# Altium schematic sheet Power Control Circuitry (updated design).SchDoc("Power Control Circuitry (updated design)")
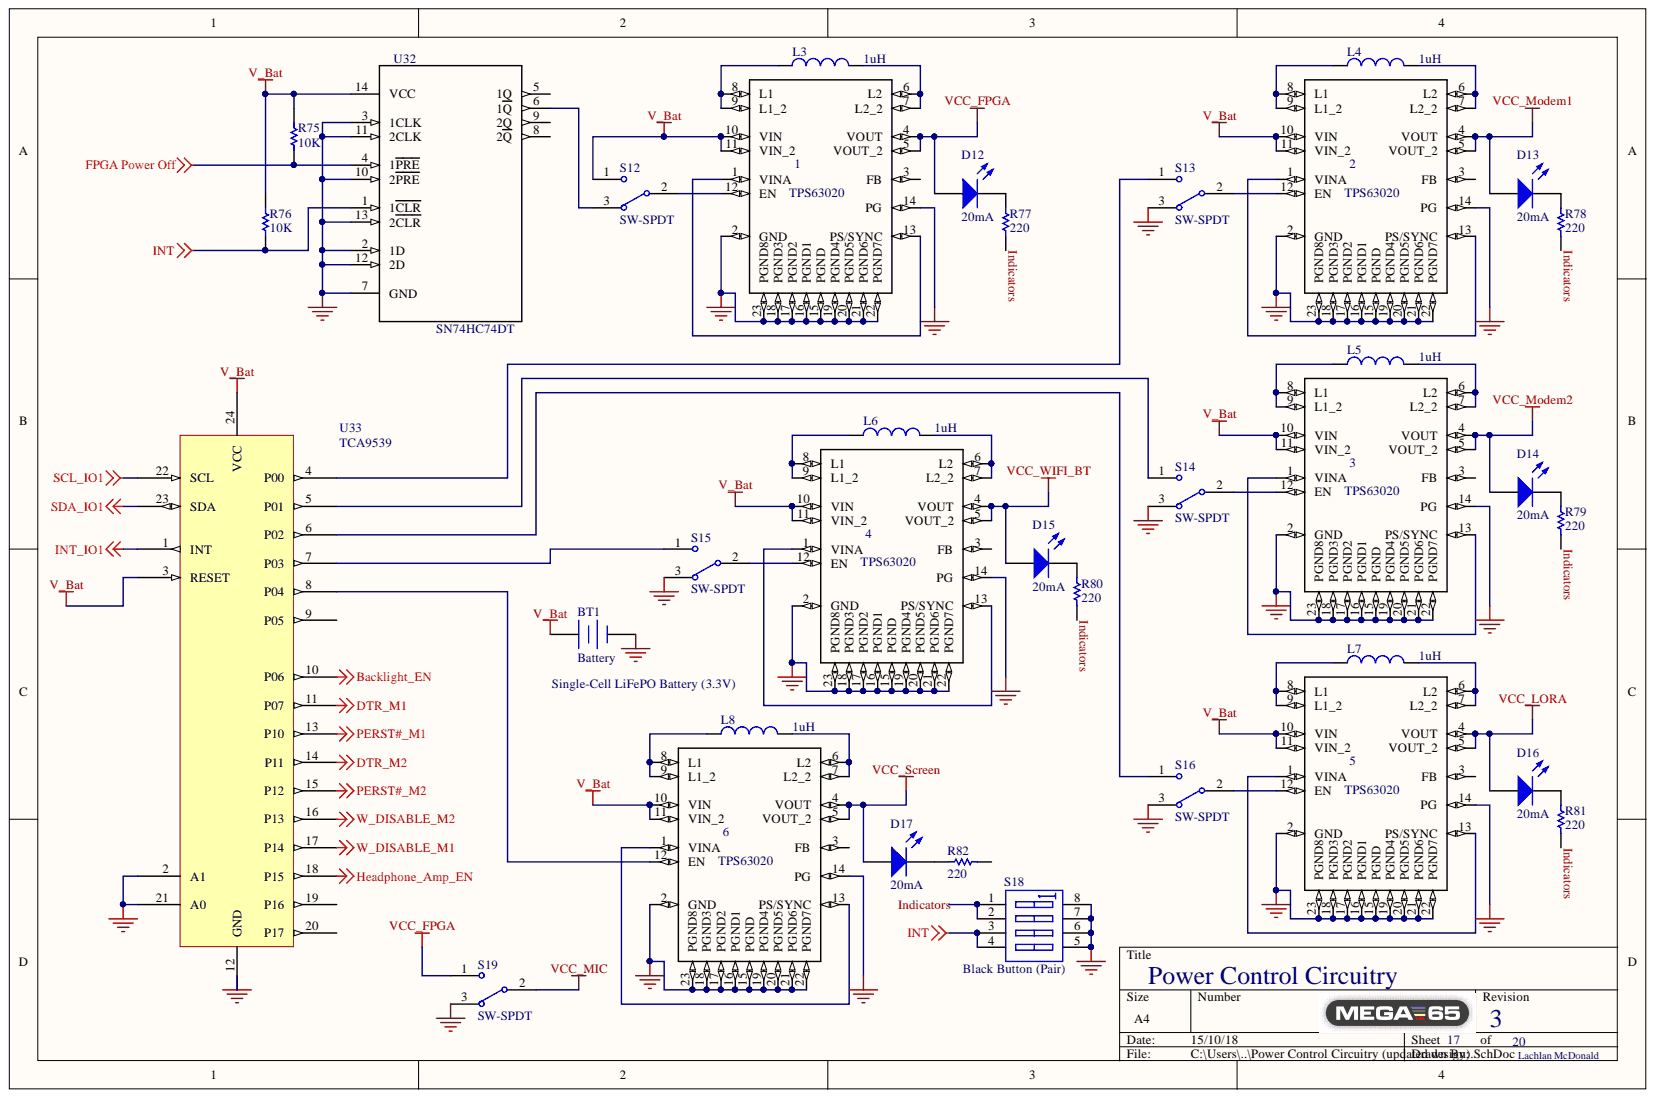
[diagram p0: Power Control Circuitry ]

## Parts
1  TPS63020  [QFN14-3X4MM]
2  TPS63020  [QFN14-3X4MM]
3  TPS63020  [QFN14-3X4MM]
4  TPS63020  [QFN14-3X4MM]
5  TPS63020  [QFN14-3X4MM]
6  TPS63020  [QFN14-3X4MM]
BT1  Battery  [BAT-2]
D12  20mA  [LEDs]
D13  20mA  [LEDs]
D14  20mA  [LEDs]
D15  20mA  [LEDs]
D16  20mA  [LEDs]
D17  20mA  [LEDs]
L3  value 1uH  [0402-A]  (hidden comment: Inductor)
L4  value 1uH  [0402-A]  (hidden comment: Inductor)
L5  value 1uH  [0402-A]  (hidden comment: Inductor)
L6  value 1uH  [0402-A]  (hidden comment: Inductor)
L7  value 1uH  [0402-A]  (hidden comment: Inductor)
L8  value 1uH  [0402-A]  (hidden comment: Inductor)
R75  10K  [RESC0805(2012)_M]
R76  10K  [RESC0805(2012)_M]
R77  220  [RESC0805(2012)_M]
R78  220  [RESC0805(2012)_M]
R79  220  [RESC0805(2012)_M]
R80  220  [RESC0805(2012)_M]
R81  220  [RESC0805(2012)_M]
R82  220  [RESC0805(2012)_M]
S12  SW-SPDT  [Switch Slide SPDT]
S13  SW-SPDT  [Switch Slide SPDT]
S14  SW-SPDT  [Switch Slide SPDT]
S15  SW-SPDT  [Switch Slide SPDT]
S16  SW-SPDT  [Switch Slide SPDT]
S18  Black Button (Pair)  [Black Button]
S19  SW-SPDT  [Switch Slide SPDT]
U32  SN74HC74DT  [SOIC127P600X175-14N]
U33  TCA9539  [PW24]

## Nets
Backlight_EN: U33.10(P06)
DTR_M1: U33.11(P07)
DTR_M2: U33.14(P11)
FPGA Power Off: R75.2 U32.4(1PRE)
GND: 1.2(GND) 1.14(PG) 1.15(PGND) 1.16(PGND1) 1.17(PGND2) 1.18(PGND3) 1.19(PGND4) 1.20(PGND5) 1.21(PGND6) 1.22(PGND7) 1.23(PGND8) 2.2(GND) 2.14(PG) 2.15(PGND) 2.16(PGND1) 2.17(PGND2) 2.18(PGND3) 2.19(PGND4) 2.20(PGND5) 2.21(PGND6) 2.22(PGND7) 2.23(PGND8) 3.2(GND) 3.14(PG) 3.15(PGND) 3.16(PGND1) 3.17(PGND2) 3.18(PGND3) 3.19(PGND4) 3.20(PGND5) 3.21(PGND6) 3.22(PGND7) 3.23(PGND8) 4.2(GND) 4.14(PG) 4.15(PGND) 4.16(PGND1) 4.17(PGND2) 4.18(PGND3) 4.19(PGND4) 4.20(PGND5) 4.21(PGND6) 4.22(PGND7) 4.23(PGND8) 5.2(GND) 5.14(PG) 5.15(PGND) 5.16(PGND1) 5.17(PGND2) 5.18(PGND3) 5.19(PGND4) 5.20(PGND5) 5.21(PGND6) 5.22(PGND7) 5.23(PGND8) 6.2(GND) 6.14(PG) 6.15(PGND) 6.16(PGND1) 6.17(PGND2) 6.18(PGND3) 6.19(PGND4) 6.20(PGND5) 6.21(PGND6) 6.22(PGND7) 6.23(PGND8) BT1.2 S13.3 S14.3 S15.3 S16.3 S18.5 S18.6 S18.7 S18.8 S19.3 U32.2(1D) U32.3(1CLK) U32.7(GND) U32.10(2PRE) U32.11(2CLK) U32.12(2D) U32.13(2CLR) U33.2(A1) U33.12(GND) U33.21(A0)
Headphone_Amp_EN: U33.18(P15)
INT: R76.2 S18.3 S18.4 U32.1(1CLR)
INT_IO1: U33.1(INT)
PERST#_M1: U33.13(P10)
PERST#_M2: U33.15(P12)
SCL_IO1: U33.22(SCL)
SDA_IO1: U33.23(SDA)
V_Bat: 1.10(VIN) 1.11(VIN_2) 2.10(VIN) 2.11(VIN_2) 3.10(VIN) 3.11(VIN_2) 4.10(VIN) 4.11(VIN_2) 5.10(VIN) 5.11(VIN_2) 6.10(VIN) 6.11(VIN_2) BT1.1 R75.1 R76.1 S12.1 U32.14(VCC) U33.3(RESET) U33.24(VCC)
VCC_FPGA: 1.4(VOUT) 1.5(VOUT_2) D12.1 S19.1
VCC_LORA: 5.4(VOUT) 5.5(VOUT_2) D16.1
VCC_MIC: S19.2
VCC_Modem1: 2.4(VOUT) 2.5(VOUT_2) D13.1
VCC_Modem2: 3.4(VOUT) 3.5(VOUT_2) D14.1
VCC_Screen: 6.4(VOUT) 6.5(VOUT_2) D17.1
VCC_WIFI_BT: 4.4(VOUT) 4.5(VOUT_2) D15.1
W_DISABLE_M1: U33.17(P14)
W_DISABLE_M2: U33.16(P13)
Indicators: R77.2 R78.2 R79.2 R80.2 R81.2 S18.1 S18.2
Net1_1: 1.1(VINA) 1.13(PS/SYNC)
Net1_6: 1.6(L2) 1.7(L2_2) L3.2
Net1_8: 1.8(L1) 1.9(L1_2) L3.1
Net1_12: 1.12(EN) S12.2
Net2_1: 2.1(VINA) 2.13(PS/SYNC)
Net2_6: 2.6(L2) 2.7(L2_2) L4.2
Net2_8: 2.8(L1) 2.9(L1_2) L4.1
Net2_12: 2.12(EN) S13.2
Net3_1: 3.1(VINA) 3.13(PS/SYNC)
Net3_6: 3.6(L2) 3.7(L2_2) L5.2
Net3_8: 3.8(L1) 3.9(L1_2) L5.1
Net3_12: 3.12(EN) S14.2
Net4_1: 4.1(VINA) 4.13(PS/SYNC)
Net4_6: 4.6(L2) 4.7(L2_2) L6.2
Net4_8: 4.8(L1) 4.9(L1_2) L6.1
Net4_12: 4.12(EN) S15.2
Net5_1: 5.1(VINA) 5.13(PS/SYNC)
Net5_6: 5.6(L2) 5.7(L2_2) L7.2
Net5_8: 5.8(L1) 5.9(L1_2) L7.1
Net5_12: 5.12(EN) S16.2
Net6_1: 6.1(VINA) 6.13(PS/SYNC)
Net6_6: 6.6(L2) 6.7(L2_2) L8.2
Net6_8: 6.8(L1) 6.9(L1_2) L8.1
Net6_12: 6.12(EN) U33.8(P04)
NetD12_2: D12.2 R77.1
NetD13_2: D13.2 R78.1
NetD14_2: D14.2 R79.1
NetD15_2: D15.2 R80.1
NetD16_2: D16.2 R81.1
NetD17_2: D17.2 R82.1
NetS12_3: S12.3 U32.6(1Q)
NetS13_1: S13.1 U33.4(P00)
NetS14_1: S14.1 U33.5(P01)
NetS15_1: S15.1 U33.7(P03)
NetS16_1: S16.1 U33.6(P02)

Not connected: 1 3(FB); 2 3(FB); 3 3(FB); 4 3(FB); 5 3(FB); 6 3(FB); R82 2; U32 5(1Q) 8(2Q) 9(2Q); U33 9(P05) 19(P16) 20(P17)

## Library data of the parts
1-6 = TPS63020: High Efficiency Single Inductor Buck-Boost Converter with 4A Switch; MF: Texas Instruments; SnapEDA_Link: https://www.snapeda.com/parts/TPS63020/Texas%2520Instruments/view-part/?ref=snap; Price: None; Package: VSON-14 Texas Instruments; MP: TPS63020; Library Name: TPS63020.IntLib; Component Kind: Standard; Availability: Unavailable
BT1 = Battery: Multicell Battery; Published: 24-Mar-1999; PackageReference: BAT-2; PackageDescription: Battery; 2 Leads; Library Name: Miscellaneous Devices.IntLib; LatestRevisionNote: Re-released for DXP Platform.; LatestRevisionDate: 17-Jul-2002; Component Kind: Standard; Publisher: Altium Limited
D12-D17 = LED2: Typical RED, GREEN, YELLOW, AMBER GaAs LED; Simulation: LED2; Published: 8-Jun-2000; PackageVersion: Feb-2002; PackageReference: 3.2X1.6X1.1; PackageDescription: SM LED; 2 Flat Leads; Library Name: Miscellaneous Devices.IntLib; LatestRevisionNote: Re-released for DXP Platform.; LatestRevisionDate: 17-Jul-2002; Component Kind: Standard; Publisher: Altium Limited
L3-L8 = Inductor: Code_IEC: 1005; Simulation: INDUCTOR; Signal Integrity: Inductor; Published: 8-Jun-2000; PackageReference: 0402-A; PackageDescription: Chip Inductor; Library Name: Miscellaneous Devices.IntLib; LatestRevisionNote: IPC-7351 Footprint Added.; LatestRevisionDate: 29-May-2009; Description: Inductor; Component Kind: Standard; Code_JEITA: 0402; Publisher: Altium Limited
R75-R82 = CMP-1013-00623-1: 10K 0.125W 1% 0805 (2012 Metric)  SMD; Value: 10000.00 Ohm; Case-Metric: 2012; Case-EIA: 0805; Tolerance: 1 %; Technology: SMT; Power: 0.125 W; Library Name: Altium Content Vault; Component Kind: Standard
S12-S16 S19 = SW-SPDT: SPDT Subminiature Toggle Switch, Right Angle Mounting, Vertical Actuation; Published: 24-Mar-1999; PackageReference: TL36WW15050; PackageDescription: Switch; 4 Leads; Library Name: Miscellaneous Devices.IntLib; LatestRevisionNote: Schematic revised, PCB Footprint replaced.; LatestRevisionDate: 17-Dec-2003; DatasheetVersion: 05-Dec-2000; ComponentLink1URL: http://www.apem.com; ComponentLink1Description: Manufacturer Link; Component Kind: Standard; Publisher: Altium Limited
S18 = SW DIP-4: DIP Switch, 4 Position, SPST; Simulation: dpsw4; Published: 24-Mar-1999; PackageVersion: Dec-2002; PackageReference: SO8; PackageDescription: 8-Pin Small Outline Integrated Circuit 1.27 mm Pitch; Library Name: Miscellaneous Devices.IntLib; LatestRevisionNote: IPC-7351 Footprint Added.; LatestRevisionDate: 29-May-2009; Component Kind: Standard; Code_JEDEC: MS-012-AA; Code_IPC: SOIC127P600-8; Publisher: Altium Limited
U32 = SN74HC74DT: Dual D-Type Positive-Edge-Triggered Flip-Flops With Clear and Preset 14-SOIC -40 to 85; MF: Texas Instruments; SnapEDA_Link: https://www.snapeda.com/parts/SN74HC74DT/Texas%20Instruments/view-part/?ref=snap; Price: 0.39 USD; Package: SOIC-14 Texas Instruments; MP: SN74HC74DT; Library Name: SN74HC74DT.IntLib; Component Kind: Standard; Availability: Good
U33 = TCA9539: Library Name: Schlib1.SchLib; Component Kind: Standard
